# Altium schematic sheet 04 ADC.SchDoc
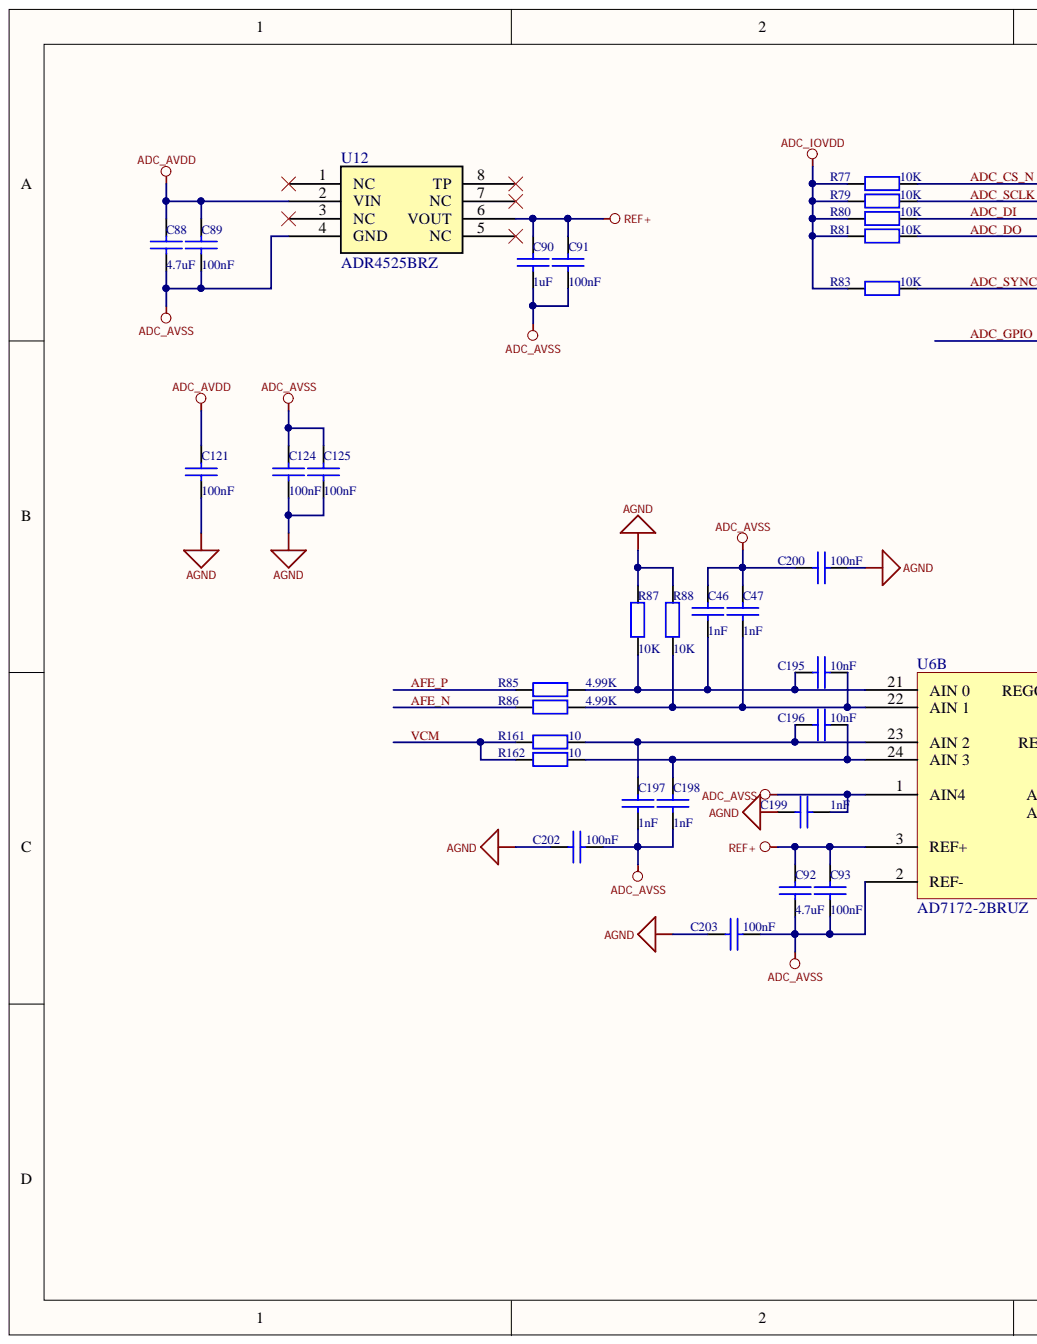
[diagram p0: 04 ADC.SchDoc, left]
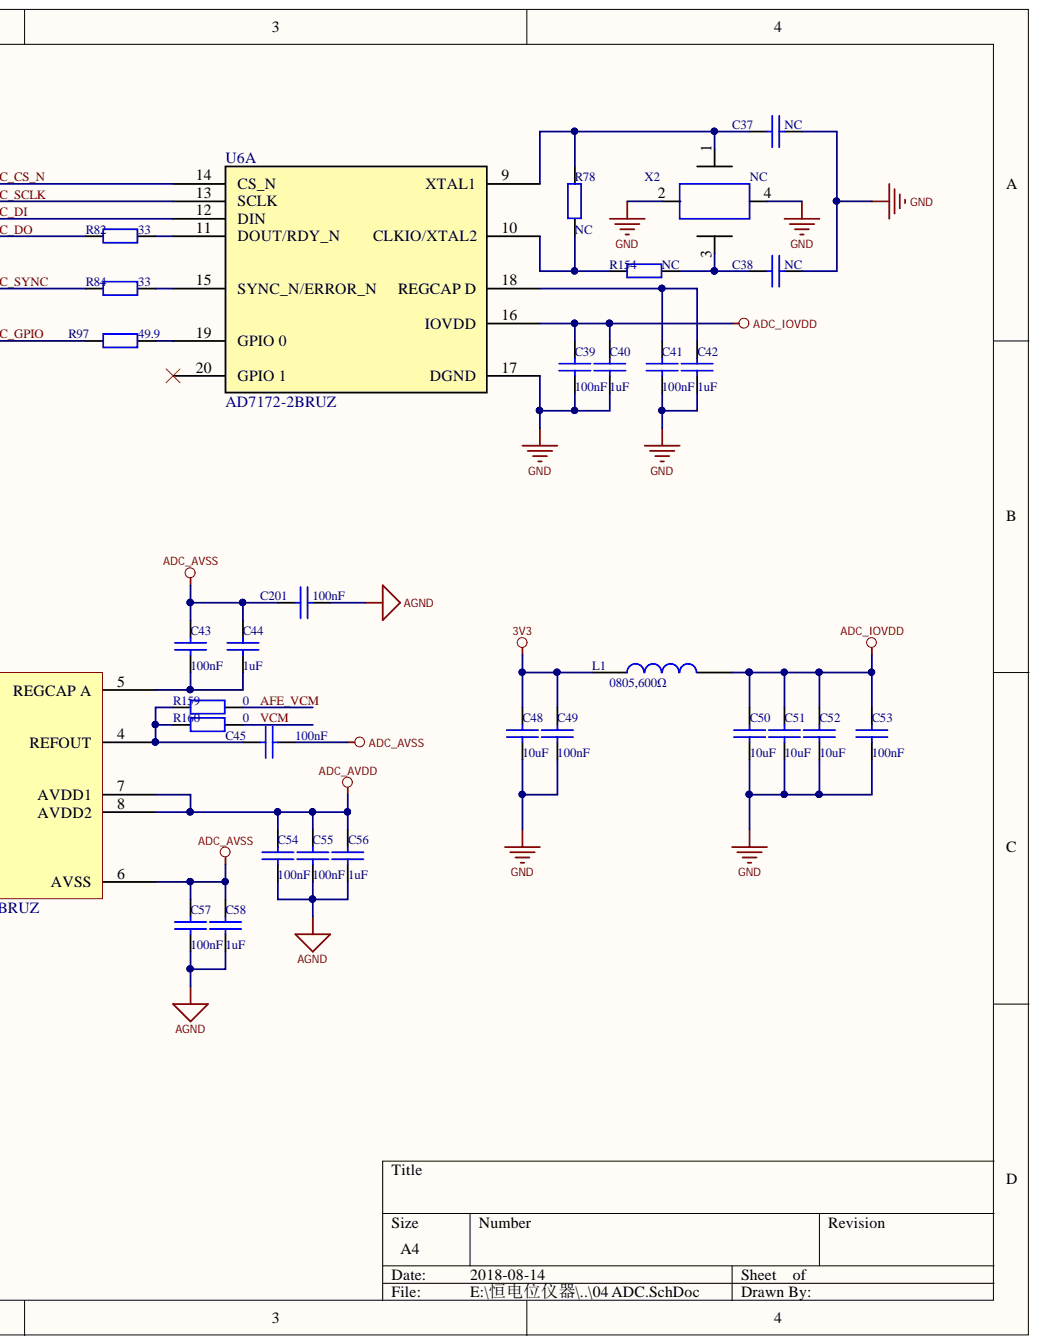
[diagram p1: 04 ADC.SchDoc, right]

## Parts
C37  20pF  [SC0603]  (value not drawn)
C38  20pF  [SC0603]  (value not drawn)
C39  100nF  [SC0402]
C40  1uF  [SC0402]
C41  100nF  [SC0402]
C42  1uF  [SC0402]
C43  100nF  [SC0402]
C44  1uF  [SC0402]
C45  100nF  [SC0402]
C46  1nF  [SC0603]
C47  1nF  [SC0603]
C48  10uF  [SC0603]
C49  100nF  [SC0402]
C50  10uF  [SC0603]
C51  10uF  [SC0603]
C52  10uF  [SC0603]
C53  100nF  [SC0402]
C54  100nF  [SC0402]
C55  100nF  [SC0402]
C56  1uF  [SC0402]
C57  100nF  [SC0402]
C58  1uF  [SC0402]
C88  4.7uF  [SC0603]
C89  100nF  [SC0402]
C90  1uF  [SC0402]
C91  100nF  [SC0402]
C92  4.7uF  [SC0603]
C93  100nF  [SC0402]
C121  100nF  [SC0402]
C124  100nF  [SC0402]
C125  100nF  [SC0402]
C195  10nF  [SC0603]
C196  10nF  [SC0603]
C197  1nF  [SC0603]
C198  1nF  [SC0603]
C199  1nF  [SC0603]
C200  100nF  [SC0402]
C201  100nF  [SC0402]
C202  100nF  [SC0402]
C203  100nF  [SC0402]
L1  0805,600Ω  [SL0805]
R77  10K±1%  value 10K  [SR0402]
R78  1M±1%  [SR0402]  (value not drawn)
R79  10K±1%  value 10K  [SR0402]
R80  10K±1%  value 10K  [SR0402]
R81  10K±1%  value 10K  [SR0402]
R82  value 33  [SR0402]  (hidden comment: 33±1%)
R83  value 10K  [SR0402]  (hidden comment: 10K±1%)
R84  value 33  [SR0402]  (hidden comment: 33±1%)
R85  value 4.99K  [SR0603]  (hidden comment: 4.99K±1%)
R86  value 4.99K  [SR0603]  (hidden comment: 4.99K±1%)
R87  10K±1%  value 10K  [SR0603]
R88  10K±1%  value 10K  [SR0603]
R97  value 49.9  [SR0402]  (hidden comment: 49.9±1%)
R154  0±5%  [SR0402]  (value not drawn)
R159  value 0  [SR0603]  (hidden comment: 0±5%)
R160  value 0  [SR0603]  (hidden comment: 0±5%)
R161  value 10  [SR0805]  (hidden comment: 10±1%)
R162  value 10  [SR0805]  (hidden comment: 10±1%)
U6  AD7172-2BRUZ  [RU_24]  (parts A,B)
U12  ADR4525BRZ  [R_8]
X2  16MHZ  [X288]  (value not drawn)

## Nets
3V3: C48.2 C49.2 L1.1
ADC_AVDD: C54.2 C55.2 C56.2 C88.2 C89.2 C121.2 U6.7(AVDD1) U6.8(AVDD2) U12.2(VIN)
ADC_AVSS: C43.2 C44.2 C45.1 C46.2 C47.2 C57.2 C58.2 C88.1 C89.1 C90.1 C91.1 C92.1 C93.1 C124.2 C125.2 C197.1 C198.1 C199.1 C200.2 C201.2 C202.1 C203.1 U6.1(AIN4) U6.2(REF-) U6.6(AVSS) U12.4(GND)
ADC_IOVDD: C39.2 C40.2 C50.2 C51.2 C52.2 C53.2 L1.2 R77.2 R79.2 R80.2 R81.2 R83.2 U6.16(IOVDD)
AGND: C54.1 C55.1 C56.1 C57.1 C58.1 C121.1 C124.1 C125.1 C199.2 C200.1 C201.1 C202.2 C203.2 R87.1 R88.1
GND: C37.2 C38.2 C39.1 C40.1 C41.1 C42.1 C48.1 C49.1 C50.1 C51.1 C52.1 C53.1 U6.17(DGND) X2.2 X2.4
REF+: C90.2 C91.2 C92.2 C93.2 U6.3(REF+) U12.6(VOUT)
ADC_CS_N: R77.1 U6.14(CS_N)
ADC_DI: R80.1 U6.12(DIN)
ADC_DO: R81.1 R82.1
ADC_GPIO: R97.1
ADC_SCLK: R79.1 U6.13(SCLK)
ADC_SYNC: R83.1 R84.1
AFE_N: R86.1
AFE_P: R85.1
AFE_VCM: R159.2
VCM: R160.2 R161.2 R162.2
NetC37_1: C37.1 R78.2 U6.9(XTAL1) X2.1
NetC38_1: C38.1 R154.2 X2.3
NetC41_2: C41.2 C42.2 U6.18(REGCAP_D)
NetC43_1: C43.1 C44.1 U6.5(REGCAP_A)
NetC45_2: C45.2 R159.1 R160.1 U6.4(REFOUT)
NetC46_1: C46.1 C195.2 R85.2 R87.2 U6.21(AIN_0)
NetC47_1: C47.1 C195.1 R86.2 R88.2 U6.22(AIN_1)
NetC196_1: C196.1 C198.2 R162.1 U6.24(AIN_3)
NetC196_2: C196.2 C197.2 R161.1 U6.23(AIN_2)
NetR78_1: R78.1 R154.1 U6.10(CLKIO/XTAL2)
NetR82_2: R82.2 U6.11(DOUT/RDY_N)
NetR84_2: R84.2 U6.15(SYNC_N/ERROR_N)
NetR97_2: R97.2 U6.19(GPIO_0)

Not connected: U6 20(GPIO_1); U12 1(NC) 3(NC) 5(NC) 7(NC) 8(TP)

## Library data of the parts
C37 C38 = CAP: Capacitor; Part_Comments: SMD陶瓷电容-50V-20pF-+/-5%-NP0-0603; Code: 101024302001; DEVICE: CC0603JRNPO9BN200; Vendor: Yageo; Voltage: 50V; Component Kind: Standard; NC: NC; Value: 20pF
C39 C41 C43 C45 C49 C53-C55 C57 C89 C91 C93 C121 C124 C125 C200-C203 = CAP: Capacitor; Part_Comments: SMD陶瓷电容-10V-100nF-+/-10%-X7R-040; Code: 101024500028; COMPONENT_HIGH_MAX: 0.55MM; DEVICE: CC0402KRX5R6BB104; Vendor: Yageo; Voltage: 10V; Component Kind: Standard; Value: 100nF
C40 C42 C44 C56 C58 C90 = CAP: Capacitor; Part_Comments: SMD陶瓷电容-10V-1uF-+/-10%-X5R-0402; Code: 101024500093; COMPONENT_HIGH_MAX: 0.55MM; DEVICE: CC0402KRX5R6BB105; Vendor: Yageo; Voltage: 10V; Component Kind: Standard; Value: 1uF
C46 C47 C197-C199 = CAP: Capacitor; Part_Comments: SMD陶瓷电容-50V-1nF-+/-5%-NPO-0402; Code: 101024500064; COMPONENT_HIGH_MAX: 0.55MM; DEVICE: CC0402JRNPO9BN102; Vendor: Yageo; Voltage: 50V; Component Kind: Standard; Value: 1nF
C48 C50-C52 = CAP: Capacitor; Part_Comments: SMD陶瓷电容-6.3V-10uF-+/-20%-X5R-0603; Code: 101024500091; COMPONENT_HIGH_MAX: 0.9MM; DEVICE: CC0603MRX5R5BB106; Vendor: Yageo; Voltage: 6.3V; Component Kind: Standard; Value: 10uF
C88 C92 = CAP: Capacitor; Part_Comments: SMD陶瓷电容-16V-4.7uF-+/-10%-X5R-0603; DEVICE: CC0603KRX5R7BB475; Vendor: Yageo; Voltage: 16V; Component Kind: Standard; Value: 4.7uF
C195 C196 = CAP: Capacitor; Part_Comments: SMD陶瓷电容-50V-10nF-+/-10%-X7R-0603; DEVICE: CC0603KRX7R9BB103; Vendor: Yageo; Voltage: 50V; Component Kind: Standard; Value: 10nF
L1 = INDUCTOR: 贴片磁珠频率-600Ω-±25%-0.25Ω-1.5A-0805; Code: 101037512601; Device: PZ2012U601; Value: PZ2012U601; Vendor: Sunlord; Component Kind: Standard; Part_Comments: 贴片磁珠频率-600Ω-±25%-0.25Ω-1.5A-0805
R77 R79-R81 R83 = RES2: Resistor; Part_Comments: 片式厚膜电阻器-0.0625W-10KOHM-+/-1%-0402; Code: 101017400055; DEVICE: RC0402FR-0710KL; Vendor: Yageo; Component Kind: Standard
R78 = Res2: Resistor; Part_Comments: 片式厚膜电阻器-0.0625W-1MOHM-+/-1%-0402; Code: 101017400043; DEVICE: RC0402FR-071ML; Value: 1M; Vendor: Yageo; Component Kind: Standard; Library Name: Miscellaneous Devices.IntLib; NC: NC; Signal Integrity: Res; Simulation: RESISTOR
R82 R84 = Res2: Resistor; Part_Comments: 片式厚膜电阻器-0.0625W-33OHM-+/-; Code: 101017400043; DEVICE: RC0402FR-0733RL; Component Kind: Standard; Library Name: Miscellaneous Devices.IntLib; Signal Integrity: Res; Simulation: RESISTOR
R85 R86 = Res2: Resistor; Part_Comments: 片式厚膜电阻器-0.1W-4.99KOHM-+/-1%-0603; Code: 101017349901; DEVICE: RC0603FR-074K99L; Vendor: Yageo; Component Kind: Standard; Library Name: Miscellaneous Devices.IntLib
R87 R88 = Res2: Resistor; Part_Comments: 片式厚膜电阻器-0.1W-10KOHM-+/-1%-0603; DEVICE: RC0603FR-0710KL; Vendor: Yageo; Component Kind: Standard; Library Name: Miscellaneous Devices.IntLib
R97 = Res2: Resistor; Part_Comments: 片式厚膜电阻器-0.0625W-49.9OHM-+/-1%-0402; Code: 101017400041; DEVICE: RC0402FR-0749R9L; Vendor: Yageo; Component Kind: Standard; Library Name: Miscellaneous Devices.IntLib; Signal Integrity: Res; Simulation: RESISTOR
R154 = Res2: Resistor; Part_Comments: 片式厚膜电阻器-0.0625W-0OHM-< 50MOHM-0402-1A; Code: 101017400045; DEVICE: RC0402JR_070RL; Value: 0; Vendor: Yageo; Component Kind: Standard; Library Name: Miscellaneous Devices.IntLib; NC: NC; Signal Integrity: Res; Simulation: RESISTOR
R159 R160 = RES2: Resistor; Part_Comments: 片式厚膜电阻器-0.1W-0OHM-+/-5%-0603; Code: 101017300001; DEVICE: RC0603JR-070RL; Vendor: Yageo; Component Kind: Standard
R161 R162 = RES2: Resistor; Part_Comments: 片式厚膜电阻器-0.125W-10OHM-+/-1%-0805; DEVICE: RC0805FR-0710RL; Vendor: Yageo; Component Kind: Standard
U6 = AD7172-2BRUZ: Vendor: ADI; Component Kind: Standard; Description: AD7172-2BRUZ; Value: AD7172-2BRUZ; Library Name: Potentiostat.SchLib
U12 = ADR4550BRZ: Ultralow Noise, High Accuracy Voltage References; Vendor: ADI; Component Kind: Standard; Value: ADR4525BRZ; Library Name: Potentiostat.SchLib
X2 = 4P_CRYSTAL: 石英晶体; Part_Comments: 晶体-12MHz-±30ppm-3225封装; CODE: 101050050066; COMPONENT_HIGH_MAX: 0.75MM; DEVICE: X2-3225-16MHz; Value: X2-3225-16MHz; Vendor: 泰艺电子; Component Kind: Standard; NC: NC; Library Name: FAST_GC_Lib.SchLib
